# Altium schematic sheet stm32-dev-board.SchDoc(stm32-dev-board)
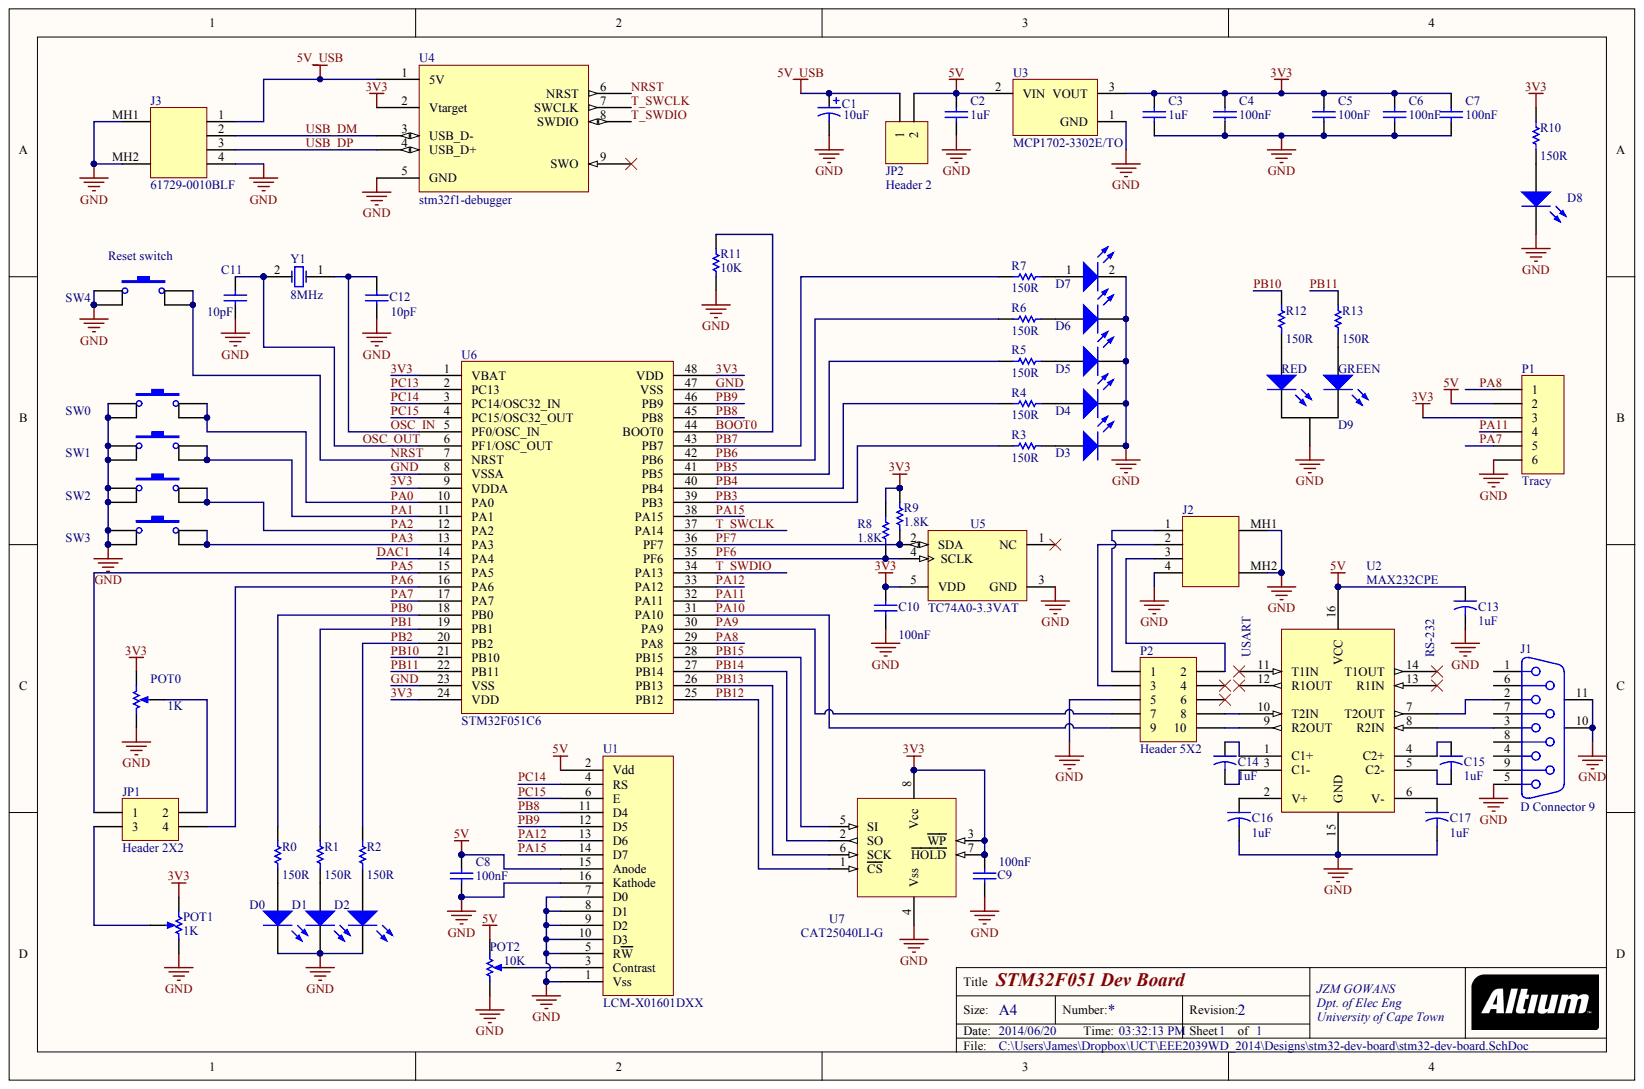
[diagram p0: stm32-dev-board.SchDoc]

## Parts
C1  value 10uF  (hidden comment: 140-REA100M1HBK0511P)
C2  value 1uF  (hidden comment: C320C104K5R5TA)
C3  value 1uF  (hidden comment: C320C104K5R5TA)
C4  value 100nF  (hidden comment: C320C104K5R5TA)
C5  value 100nF  (hidden comment: C320C104K5R5TA)
C6  value 100nF  (hidden comment: C320C104K5R5TA)
C7  value 100nF  (hidden comment: C320C104K5R5TA)
C8  value 100nF  (hidden comment: C320C104K5R5TA)
C9  value 100nF  (hidden comment: C320C104K5R5TA)
C10  value 100nF  (hidden comment: C320C104K5R5TA)
C11  value 10pF  (hidden comment: 140-500N2-100J-RC)
C12  value 10pF  (hidden comment: 140-500N2-100J-RC)
C13  1uF
C14  1uF
C15  1uF
C16  1uF
C17  1uF
D0  C503B-AAN-CY0B0251  (value not drawn)
D1  C503B-AAN-CY0B0251  (value not drawn)
D2  C503B-AAN-CY0B0251  (value not drawn)
D3  C503B-AAN-CY0B0251  (value not drawn)
D4  C503B-AAN-CY0B0251  (value not drawn)
D5  C503B-AAN-CY0B0251  (value not drawn)
D6  C503B-AAN-CY0B0251  (value not drawn)
D7  C503B-AAN-CY0B0251  (value not drawn)
D8  78-TLHG5201  (value not drawn)
D9  Dual Red/Greed LED  (value not drawn)
J1  D Connector 9
J2  61729-0010BLF
J3  61729-0010BLF
JP1  Header 2X2
JP2  Header 2
P1  Tracy
P2  Header 5X2
POT0  value 1K  (hidden comment: PT15(N/G)V15)
POT1  1K
POT2  10K
R0  value 150R  (hidden comment: 299-150-RC)
R1  value 150R  (hidden comment: 299-150-RC)
R2  value 150R  (hidden comment: 299-150-RC)
R3  value 150R  (hidden comment: 299-150-RC)
R4  value 150R  (hidden comment: 299-150-RC)
R5  value 150R  (hidden comment: 299-150-RC)
R6  value 150R  (hidden comment: 299-150-RC)
R7  value 150R  (hidden comment: 299-150-RC)
R8  value 1.8K  (hidden comment: 299-150-RC)
R9  value 1.8K  (hidden comment: 299-150-RC)
R10  value 150R  (hidden comment: 299-150-RC)
R11  value 10K  (hidden comment: 270-10K-RC)
R12  value 150R  (hidden comment: 299-150-RC)
R13  value 150R  (hidden comment: 299-150-RC)
SW0  SW
SW1  SW
SW2  SW
SW3  SW
SW4  SW
U1  LCM-X01601DXX
U2  MAX232CPE
U3  MCP1702-3302E/TO
U4  stm32f1-debugger
U5  TC74A0-3.3VAT
U6  STM32F051C6
U7  CAT25040LI-G
Y1  8MHz

## Nets
5V: C2.2 C8.2 C13.1 JP2.2 P1.2 POT2.3 U1.2(Vdd) U1.15(Anode) U2.16(VCC) U3.2
5V_USB: C1.1 J3.1 JP2.1 U4.1(5V)
3V3: C3.2 C4.2 C5.2 C6.2 C7.2 C9.1 C10.2 P1.3 POT0.1 POT1.1 R8.1 R9.1 R10.2 U3.3 U4.2(Vtarget) U5.5(VDD) U6.1(VBAT) U6.9(VDDA) U6.24(VDD) U6.48(VDD) U7.3(WP) U7.7(HOLD) U7.8(Vcc)
BOOT0: R11.2 U6.44(BOOT0)
DAC1: U6.14(PA4)
GND: C1.2 C2.1 C3.1 C4.1 C5.1 C6.1 C7.1 C8.1 C9.2 C10.1 C11.1 C12.2 C13.2 C16.2 C17.2 D0.2 D1.2 D2.2 D3.2 D4.2 D5.2 D6.2 D7.2 D8.2 D9.2 J1.5 J1.10 J1.11 J2.4 J2.MH1 J2.MH2 J3.4 J3.MH1 J3.MH2 P1.6 P2.5 POT0.2 POT1.2 POT2.1 R11.1 SW0.1 SW0.3 SW1.1 SW1.3 SW2.1 SW2.3 SW3.1 SW3.3 SW4.1 SW4.3 U1.1(Vss) U1.5(RW) U1.7(D0) U1.8(D1) U1.9(D2) U1.10(D3) U1.16(Kathode) U2.15(GND) U3.1 U4.5(GND) U5.3(GND) U6.8(VSSA) U6.23(VSS) U6.47(VSS) U7.4(Vss)
NRST: SW4.2 SW4.4 U4.6(NRST) U6.7(NRST)
OSC_IN: C12.1 U6.5(PF0/OSC_IN) Y1.1
OSC_OUT: C11.2 U6.6(PF1/OSC_OUT) Y1.2
PA0: SW0.2 SW0.4 U6.10(PA0)
PA1: SW1.2 SW1.4 U6.11(PA1)
PA2: SW2.2 SW2.4 U6.12(PA2)
PA3: SW3.2 SW3.4 U6.13(PA3)
PA5: JP1.1 U6.15(PA5)
PA6: JP1.4 U6.16(PA6)
PA7: P1.5 U6.17(PA7)
PA8: P1.1 U6.29(PA8)
PA9: P2.7 U6.30(PA9)
PA10: P2.9 U6.31(PA10)
PA11: P1.4 U6.32(PA11)
PA12: U1.13(D6) U6.33(PA12)
PA15: U1.14(D7) U6.38(PA15)
PB0: R0.2 U6.18(PB0)
PB1: R1.2 U6.19(PB1)
PB2: R2.2 U6.20(PB2)
PB3: R3.2 U6.39(PB3)
PB4: R4.2 U6.40(PB4)
PB5: R5.2 U6.41(PB5)
PB6: R6.2 U6.42(PB6)
PB7: R7.2 U6.43(PB7)
PB8: U1.11(D4) U6.45(PB8)
PB9: U1.12(D5) U6.46(PB9)
PB10: R12.2 U6.21(PB10)
PB11: R13.2 U6.22(PB11)
PB12: U6.25(PB12) U7.1(CS)
PB13: U6.26(PB13) U7.6(SCK)
PB14: U6.27(PB14) U7.2(SO)
PB15: U6.28(PB15) U7.5(SI)
PC13: U6.2(PC13)
PC14: U1.4(RS) U6.3(PC14/OSC32_IN)
PC15: U1.6(E) U6.4(PC15/OSC32_OUT)
PF6: R8.2 U5.4(SCLK) U6.35(PF6)
PF7: R9.2 U5.2(SDA) U6.36(PF7)
T_SWCLK: U4.7(SWCLK) U6.37(PA14)
T_SWDIO: U4.8(SWDIO) U6.34(PA13)
USB_DM: J3.2 U4.3(USB_D-)
USB_DP: J3.3 U4.4(USB_D+)
NetC14_1: C14.1 U2.1(C1+)
NetC14_2: C14.2 U2.3(C1-)
NetC15_1: C15.1 U2.4(C2+)
NetC15_2: C15.2 U2.5(C2-)
NetC16_1: C16.1 U2.2(V+)
NetC17_1: C17.1 U2.6(V-)
NetD0_1: D0.1 R0.1
NetD1_1: D1.1 R1.1
NetD2_1: D2.1 R2.1
NetD3_1: D3.1 R3.1
NetD4_1: D4.1 R4.1
NetD5_1: D5.1 R5.1
NetD6_1: D6.1 R6.1
NetD7_1: D7.1 R7.1
NetD8_1: D8.1 R10.1
NetD9_1: D9.1 R12.1
NetD9_3: D9.3 R13.1
NetJ1_2: J1.2 U2.7(T2OUT)
NetJ1_3: J1.3 U2.8(R2IN)
NetJ2_1: J2.1 P2.1
NetJ2_2: J2.2 P2.3
NetJ2_3: J2.3 P2.2
NetJP1_2: JP1.2 POT0.3
NetJP1_3: JP1.3 POT1.3
NetP2_8: P2.8 U2.10(T2IN)
NetP2_10: P2.10 U2.9(R2OUT)
NetPOT2_2: POT2.2 U1.3(Contrast)

Not connected: J1 1 4 6 7 8 9; P2 4 6; U2 11(T1IN) 12(R1OUT) 13(R1IN) 14(T1OUT); U4 9(SWO); U5 1(NC)

## Library data of the parts
J1 = (no symbol name): PackageDocument: O; Positions: 9; Gender: Receptacle; Published: 15-Jun-2001; LatestRevisionDate: 17-Jul-2002; LatestRevisionNote: Re-released for DXP Platform.; DatasheetDocument: 1-Sep-1999; PackageReference: 788750; Orientation: Right Angle; Publisher: Altium Limited
J2 J3 = (no symbol name): Manufacturer: FCI Electronics; Number of Contacts: 4; Packing: Tube; Gender: Receptacle; Specifications: USB 2.0; Connector Type: USB-B; ComponentLink1URL: http://portal.fciconnect.com/; DatasheetVersion: Rev. V; PartNumber: 61729-0010BLF; ComponentLink3Description: Package Specification; PackageDescription: 6-Pin, Through Hole, Body 12.2 x 16 mm; ComponentLink2Description: Datasheet; Mounting Technology: Through Hole; PackageReference: 61729-0010BLF; ComponentLink1Description: Manufacturer URL; ComponentLink3URL: http://portal.fciconnect.com/Comergent//fci/drawing/61729.pdf; ComponentLink2URL: http://portal.fciconnect.com/Comergent//fci/drawing/61729.pdf; RoHS: TRUE
POT0-POT2 = (no symbol name): Sim Note: Enter the Resistance value and the set point into parameter fields.; Set Position: 0.5; Published: 8-Jun-2000; LatestRevisionDate: 17-Jul-2002; LatestRevisionNote: Re-released for DXP Platform.; PackageReference: VR5; PackageDescription: Variable Resistor, Thru-Hole; 3 Leads; Publisher: Altium Limited
  POT1: Value: 1K
  POT2: Value: 10K
C1-C17 D0-D9 JP1 JP2 P1 P2 R0-R13 SW0-SW4 U1-U7 Y1 = (no symbol name)
  C1: Published: 8-Jun-2000; LatestRevisionDate: 17-Jul-2002; LatestRevisionNote: Re-released for DXP Platform.; PackageReference: RB7.6-15; PackageDescription: Polarized Capacitor (Radial); 2 Leads; Mouser part number: 140-REA100M1HBK0511P
  C2-C12: Published: 8-Jun-2000; LatestRevisionDate: 17-Jul-2002; LatestRevisionNote: Re-released for DXP Platform.; PackageReference: RAD-0.3; PackageDescription: Radial Cap, Thru-Hole; 2 Leads; 0.3 in Pin Spacing
  C13-C17: Published: 23-Sep-2002; PackageReference: CAPR5-4X5; PackageDescription: Capacitor; 2 Leads; Value: 1uF
  D0-D8: Published: 8-Jun-2000; LatestRevisionDate: 08-Jul-2005; LatestRevisionNote: Mech Layer 1 removed.; PackageReference: LED-throughhole-5mm; PackageDescription: LED; 2 Leads
  JP1 JP2 P1 P2: LatestRevisionDate: 17-Jul-2002; LatestRevisionNote: Re-released for DXP Platform.
  R0-R9 R11-R13: Published: 8-Jun-2000; LatestRevisionDate: 17-Jul-2002; LatestRevisionNote: Re-released for DXP Platform.; PackageReference: AXIAL-0.3; PackageDescription: Axial Device, Thru-Hole; 2 Leads; 0.3 in Pin Spacing
  R10: Published: 8-Jun-2000; LatestRevisionDate: 17-Jul-2002; LatestRevisionNote: Re-released for DXP Platform.; PackageReference: AXIAL-0.3; PackageDescription: Axial Device, Thru-Hole; 2 Leads; 0.3 in Pin Spacing; mouser part number: 299-150-RC
  U3: PackageVersion: revBB, Aug-2009; DatasheetVersion: revD, Jun-2009; PackageDescription: 3-Lead Plastic Transistor Outline (TO) [TO-92]; PackageReference: TO-92-TO3
  U5: DatasheetVersion: revC, Oct-2002; PackageVersion: revBB, Aug-2009; PackageDescription: 5-Lead Plastic Transistor Outline (AT) [TO-220]; PackageReference: TO-220-AT5
  Y1: Published: 8-Jun-2000; LatestRevisionDate: 17-Jul-2002; LatestRevisionNote: Re-released for DXP Platform.; PackageReference: R38; PackageDescription: Crystal, Thru-Hole; 2 Leads; Body 3.1 x 8.2 mm (Dia.xH)
  C1-C17 D0-D8 JP1 JP2 P1 P2 R0-R13 Y1: Publisher: Altium Limited
